# Altium schematic sheet GPS.SchDoc
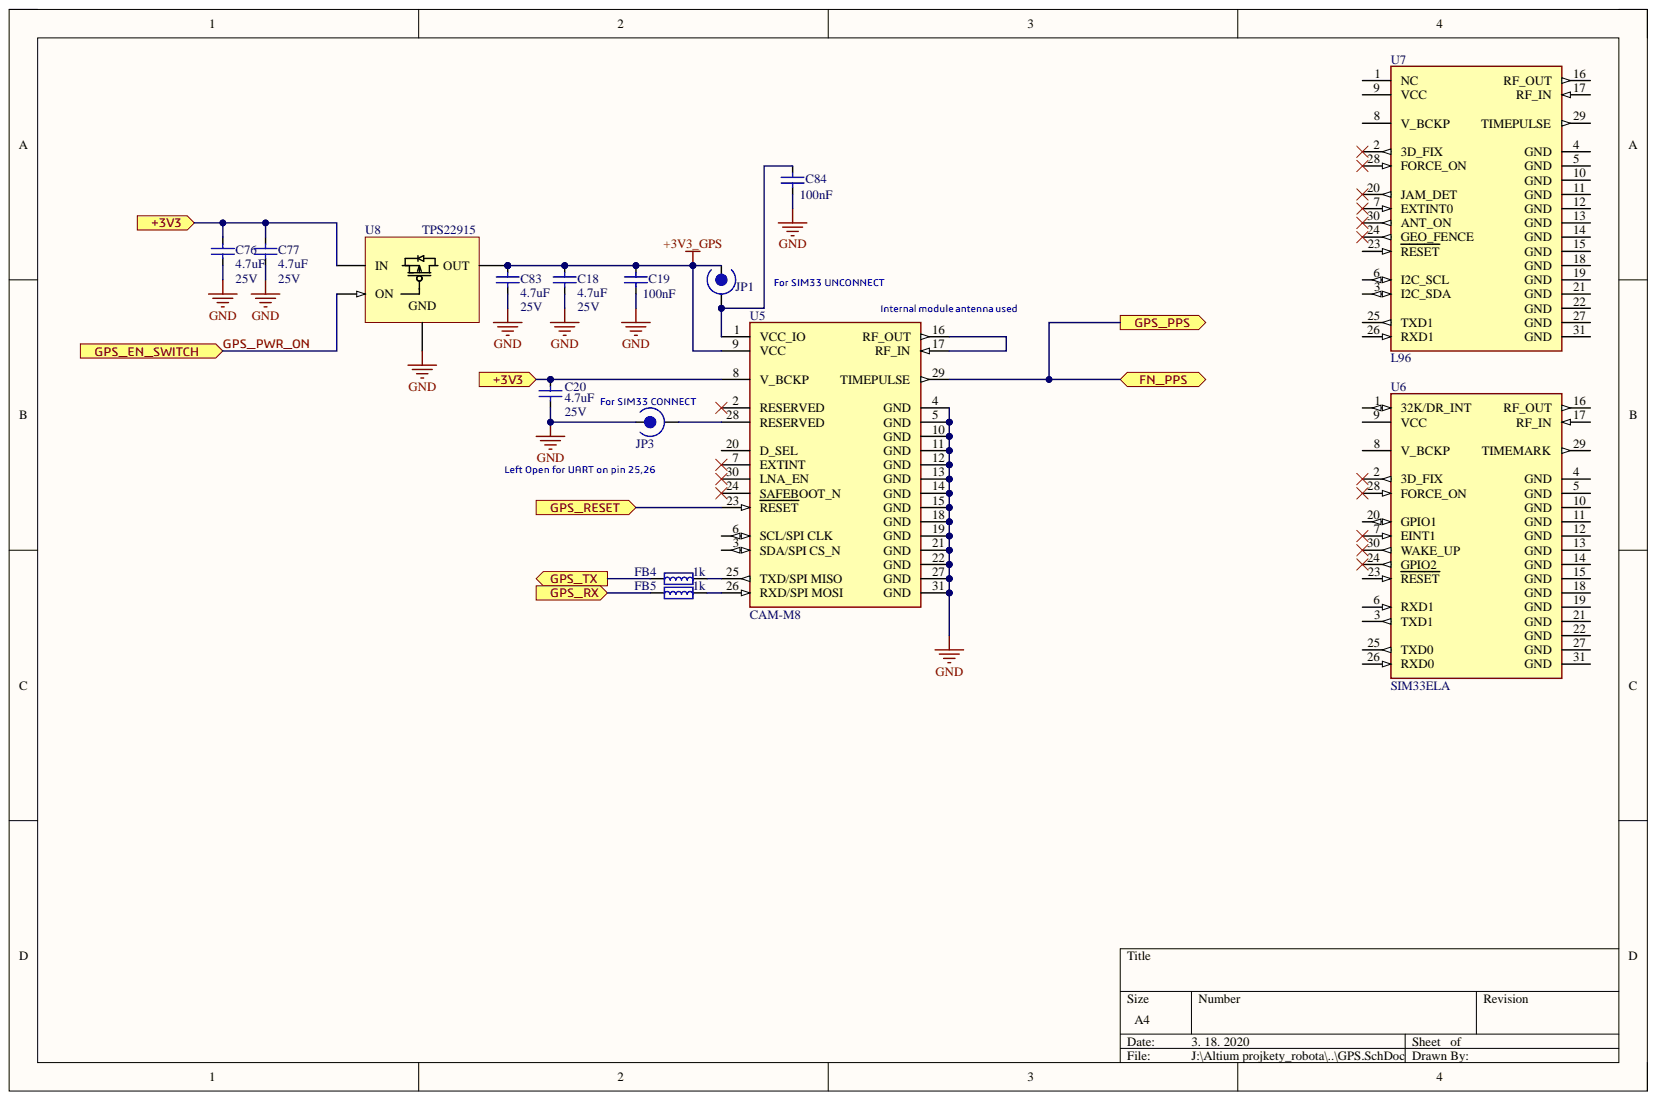
[diagram p0: GPS.SchDoc]

## Parts
C18  4.7uF  Voltage: 25V  [CAPACITOR_0603_(1608)]
C19  100nF  [CAPACITOR_0402_(1005)]
C20  4.7uF  Voltage: 25V  [CAPACITOR_0603_(1608)]
C76  4.7uF  Voltage: 25V  [CAPACITOR_0603_(1608)]
C77  4.7uF  Voltage: 25V  [CAPACITOR_0603_(1608)]
C83  4.7uF  Voltage: 25V  [CAPACITOR_0603_(1608)]
C84  100nF  [CAPACITOR_0402_(1005)]
FB4  1k  [FERRITE BEAD_0402_(1005)]
FB5  1k  [FERRITE BEAD_0402_(1005)]
JP1  Jumper  [JUMPER OFF SMD]  (value not drawn)  (no BOM)
JP3  Jumper  [JUMPER OFF SMD]  (value not drawn)  (no BOM)
U5  CAM-M8  [GPS_CAM-M8 + Quectel GNSS L96]
U8  TPS22915  [DSBGA4_0.4mmpitch]

## Ports
+3V3, FN_PPS, GPS_EN_SWITCH, GPS_PPS, GPS_RESET, GPS_RX, GPS_TX

## Nets
+3V3_GPS: C18.1 C19.1 C83.1 JP1.2 U5.9(VCC) U8.A1(OUT)
GND: C18.2 C19.2 C20.2 C76.2 C77.2 C83.2 C84.2 JP3.2 U5.4(GND) U5.5(GND) U5.10(GND) U5.11(GND) U5.12(GND) U5.13(GND) U5.14(GND) U5.15(GND) U5.18(GND) U5.19(GND) U5.21(GND) U5.22(GND) U5.27(GND) U5.31(GND) U8.B1
+3V3: C20.1 C76.1 C77.1 U5.8(V_BCKP) U8.A2(IN) ; port +3V3
FN_PPS: U5.29(TIMEPULSE) ; port FN_PPS, port GPS_PPS
GPS_PWR_ON: U8.B2(ON) ; port GPS_EN_SWITCH
GPS_RESET: U5.23(RESET) ; port GPS_RESET
GPS_RX: FB5.1 ; port GPS_RX
GPS_TX: FB4.1 ; port GPS_TX
NetC84_1: C84.1 JP1.1 U5.1(VCC_IO)
NetFB4_2: FB4.2 U5.25(TXD/SPI_MISO)
NetFB5_2: FB5.2 U5.26(RXD/SPI_MOSI)
NetJP3_1: JP3.1 U5.28(RESERVED)
NetU5_16: U5.16(RF_OUT) U5.17(RF_IN)

Not connected: U5 2(RESERVED) 3(SDA/SPI_CS_N) 6(SCL/SPI_CLK) 7(EXTINT) 20(D_SEL) 24(SAFEBOOT_N) 30(LNA_EN)

## Library data of the parts
C18 C20 C76 C77 C83 = CAPACITOR: Manufacturer 1: Murata; Manufacturer Part Number 1: GRM188R61E475KE11D; Dielectric: X5R; ESR: 0.28R; Material: Ceramic; Tolerance: 10%; Capacitance: 4.7uF; Case Code (Imperial): 0603; Case Code (Metric): 1608; Case/Package: 0603; Component Kind: Standard; ComponentLink1Description: https://datasheet.ciiva.com/46444/1769893-46444982.pdf?src-supplier=Element14; ComponentLink1URL: https://datasheet.ciiva.com/46444/1769893-46444982.pdf?src-supplier=Element14; ComponentLink2Description: https://datasheet.ciiva.com/46444/1769893-46444983.pdf?src-supplier=Element14; ComponentLink2URL: https://datasheet.ciiva.com/46444/1769893-46444983.pdf?src-supplier=Element14; ComponentLink3Description: https://datasheet.ciiva.com/14891/partnumbering-e-01-14891102.pdf?src-supplier=Digi-Key; ComponentLink3URL: https://datasheet.ciiva.com/14891/partnumbering-e-01-14891102.pdf?src-supplier=Digi-Key; ComponentLink4Description: https://datasheet.ciiva.com/14891/partnumbering-e-01-14891103.pdf?src-supplier=Digi-Key; ComponentLink4URL: https://datasheet.ciiva.com/14891/partnumbering-e-01-14891103.pdf?src-supplier=Digi-Key; Depth: 0.8mm; Description: CAPACITOR; Height: 0.8mm; Lead Free: Lead Free; Length: 1.6mm; Max Operating Temperature: 85°C; Min Operating Temperature: -55°C; Mount: Surface Mount; Package Quantity: 4000; Packaging: Cut Tape; Pricing 1: 10=0,35700, 100=100,00000, 500=0,15900, 1000=0,12800, 2000=0,10200 (CHF); REACH SVHC: No SVHC; RoHS: Yes; Stock 1: 95119; Supplier 1: Farnell; Supplier Part Number 1: 2426959; Thickness: 0.031inch; Voltage Rating: 25V; Voltage Rating (DC): 25V; Width: 0.031inch; Library Name: RLCFB.SchLib
C19 C84 = CAPACITOR: ESR: 0.28R; Voltage Rating: 10V; Capacitance: 100nF; Component Kind: Standard; Description: CAPACITOR; Library Name: RLCFB.SchLib
FB4 FB5 = FERRITE_BEAD: Manufacturer 1: Murata; Manufacturer Part Number 1: BLM15HD102SN1D; Current Rating: 250mA; DC Resistance (DCR): 1.25R; Frequency: 100MHz; Impedance: 1kR; Material: Steel,Ferrite; Number of Circuits: 1; Shielding: Unshielded; Tolerance: 25%; Case Code (Imperial): 0402; Case Code (Metric): 1005; Case/Package: 0402; Component Kind: Standard; ComponentLink1Description: https://datasheet.ciiva.com/11799/0900766b811b5866-11799124.pdf?src-supplier=RSComponents; ComponentLink1URL: https://datasheet.ciiva.com/11799/0900766b811b5866-11799124.pdf?src-supplier=RSComponents; ComponentLink2Description: https://datasheet.ciiva.com/18089/getdatasheetpartid-153027-18089625.pdf?src-supplier=Verical; ComponentLink2URL: https://datasheet.ciiva.com/18089/getdatasheetpartid-153027-18089625.pdf?src-supplier=Verical; ComponentLink3Description: https://datasheet.ciiva.com/27054/1844707-27054220.pdf?src-supplier=Jameco; ComponentLink3URL: https://datasheet.ciiva.com/27054/1844707-27054220.pdf?src-supplier=Jameco; ComponentLink4Description: https://datasheet.ciiva.com/41449/001172200-da-01-en-drossel-0402-1000r-21-0x0-5mm-10000st-41449488.pdf?src-supplier=Conrad; ComponentLink4URL: https://datasheet.ciiva.com/41449/001172200-da-01-en-drossel-0402-1000r-21-0x0-5mm-10000st-41449488.pdf?src-supplier=Conrad; ComponentLink5Description: https://datasheet.ciiva.com/41425/001171683-da-01-en-chip-drossel0402-1000r-250ma-1-0x0-5mm-41425767.pdf?src-supplier=Conrad; ComponentLink5URL: https://datasheet.ciiva.com/41425/001171683-da-01-en-chip-drossel0402-1000r-250ma-1-0x0-5mm-41425767.pdf?src-supplier=Conrad; ComponentLink6Description: https://datasheet.ciiva.com/3081/l0110s0101blm15h-3081604.pdf?src-supplier=Avnet; ComponentLink6URL: https://datasheet.ciiva.com/3081/l0110s0101blm15h-3081604.pdf?src-supplier=Avnet; ComponentLink7Description: https://datasheet.ciiva.com/3081/l0110s0101blm15h-3081605.pdf?src-supplier=Avnet; ComponentLink7URL: https://datasheet.ciiva.com/3081/l0110s0101blm15h-3081605.pdf?src-supplier=Avnet; ComponentLink8Description: https://datasheet.ciiva.com/1012/l0110s0101blm15h-1012794.pdf?src-supplier=Digi-Key; ComponentLink8URL: https://datasheet.ciiva.com/1012/l0110s0101blm15h-1012794.pdf?src-supplier=Digi-Key; ComponentLink9Description: https://datasheet.ciiva.com/16373/l0110s0101blm15h-16373866.pdf?src-supplier=Future+Electronics; ComponentLink9URL: https://datasheet.ciiva.com/16373/l0110s0101blm15h-16373866.pdf?src-supplier=Future+Electronics; ComponentLink10Description: https://datasheet.ciiva.com/1012/l0110s0101blm15h-1012795.pdf?src-supplier=Digi-Key; ComponentLink10URL: https://datasheet.ciiva.com/1012/l0110s0101blm15h-1012795.pdf?src-supplier=Digi-Key; ComponentLink11Description: https://datasheet.ciiva.com/1466/5168-1466859.pdf?src-supplier=Element14; ComponentLink11URL: https://datasheet.ciiva.com/1466/5168-1466859.pdf?src-supplier=Element14; ComponentLink12Description: https://datasheet.ciiva.com/1012/l0110s0101blm15h-1012796.pdf?src-supplier=Digi-Key; ComponentLink12URL: https://datasheet.ciiva.com/1012/l0110s0101blm15h-1012796.pdf?src-supplier=Digi-Key; ComponentLink13Description: https://datasheet.ciiva.com/1466/5168-1466860.pdf?src-supplier=Element14; ComponentLink13URL: https://datasheet.ciiva.com/1466/5168-1466860.pdf?src-supplier=Element14; Contact Plating: Tin,Matte; Core Material: Ferrite; DC Current: 250mA; Depth: 0.5mm; Description: FERRITE_BEAD; Height: 0.5mm; Impedance@100MHz: 1k; Lead Free: Lead Free; Length: 1mm; Max Current Rating: 250mA; Max DC Current: 250mA; Max Operating Temperature: 125°C; Military Standard: Not; Min Operating Temperature: -55°C; Number of Lines: 1; Number of Pins: 2; Package Quantity: 1; Packaging: Tape and Reel; Pricing 1: 10=0,06380, 100=0,03950, 500=0,02860, 2500=0,02130, 5000=0,01890, 10000=0,01690, 50000=0,01480 (EUR); Radiation Hardening: No; REACH SVHC: No SVHC; Resistance: 1.25R; RoHS: Yes; Stock 1: 51262; Supplier 1: Farnell; Supplier Part Number 1: 1515785; Termination: SMD/SMT; Test Frequency: 100MHz; Width: 0.5mm; Library Name: RLCFB.SchLib
JP1 JP3 = Jumper SMD: Description: Jumper; Library Name: Source.SchLib
U5 = CAM-M8: u-blox CAM GNSS antenna module; Component Kind: Standard; ComponentLink1Description: CAM-M8 series; ComponentLink1URL: https://www.u-blox.com/en/product/cam-m8-series#tab-documentation-resources; Library Name: BB1_SCH_Library.SchLib; Manufacturer: u-blox; Part Description: u-blox CAM GNSS antenna module; Value: CAM-M8
U8 = TPS22915: TPS22915  Power Switch ICs - Power Distribution 5.5V, 2A, 38mOhm Load Switch   tR=64 µs  QUICK OUTPUT DISCHARGE=Yes; Manufacturer 1: Texas Instruments; Manufacturer Part Number 1: TPS22915BYFPR; Interface: On/Off; Number of Channels: 1; Output Configuration: High Side; Quiescent Current: 7.7uA; Component Kind: Standard; ComponentLink1Description: http://www.ti.com/lit/ds/symlink/tps22915.pdf?src-supplier=Digi-Key; ComponentLink1URL: http://www.ti.com/lit/ds/symlink/tps22915.pdf?src-supplier=Digi-Key; ComponentLink2Description: http://www.ti.com/general/docs/lit/getliterature.tsp?genericPartNumber=TPS22915&&fileType=pdf&src-supplier=Avnet; ComponentLink2URL: http://www.ti.com/general/docs/lit/getliterature.tsp?genericPartNumber=TPS22915&&fileType=pdf&src-supplier=Avnet; ComponentLink3Description: http://www.ti.com/lit/gpn/tps22915?src-supplier=Mouser; ComponentLink3URL: http://www.ti.com/lit/gpn/tps22915?src-supplier=Mouser; Lead Free: Lead Free; Library Name: BB1_SCH_Library.SchLib; Max Operating Temperature: 85°C; Max Output Current: 2A; Min Operating Temperature: -40°C; Mount: Surface Mount; Number of Outputs: 1; Number of Pins: 4; Packaging: Tape and Reel; Pricing 1: 1=0,42000, 10=0,35000, 100=0,21300, 1000=0,16400, 3000=0,14000, 9000=0,13000 (USD); RoHS: Yes; Stock 1: 3444; Supplier 1: Mouser; Supplier Part Number 1: 595-TPS22915BYFPR
